# Altium schematic sheet arm_v3.SchDoc(arm_v3)
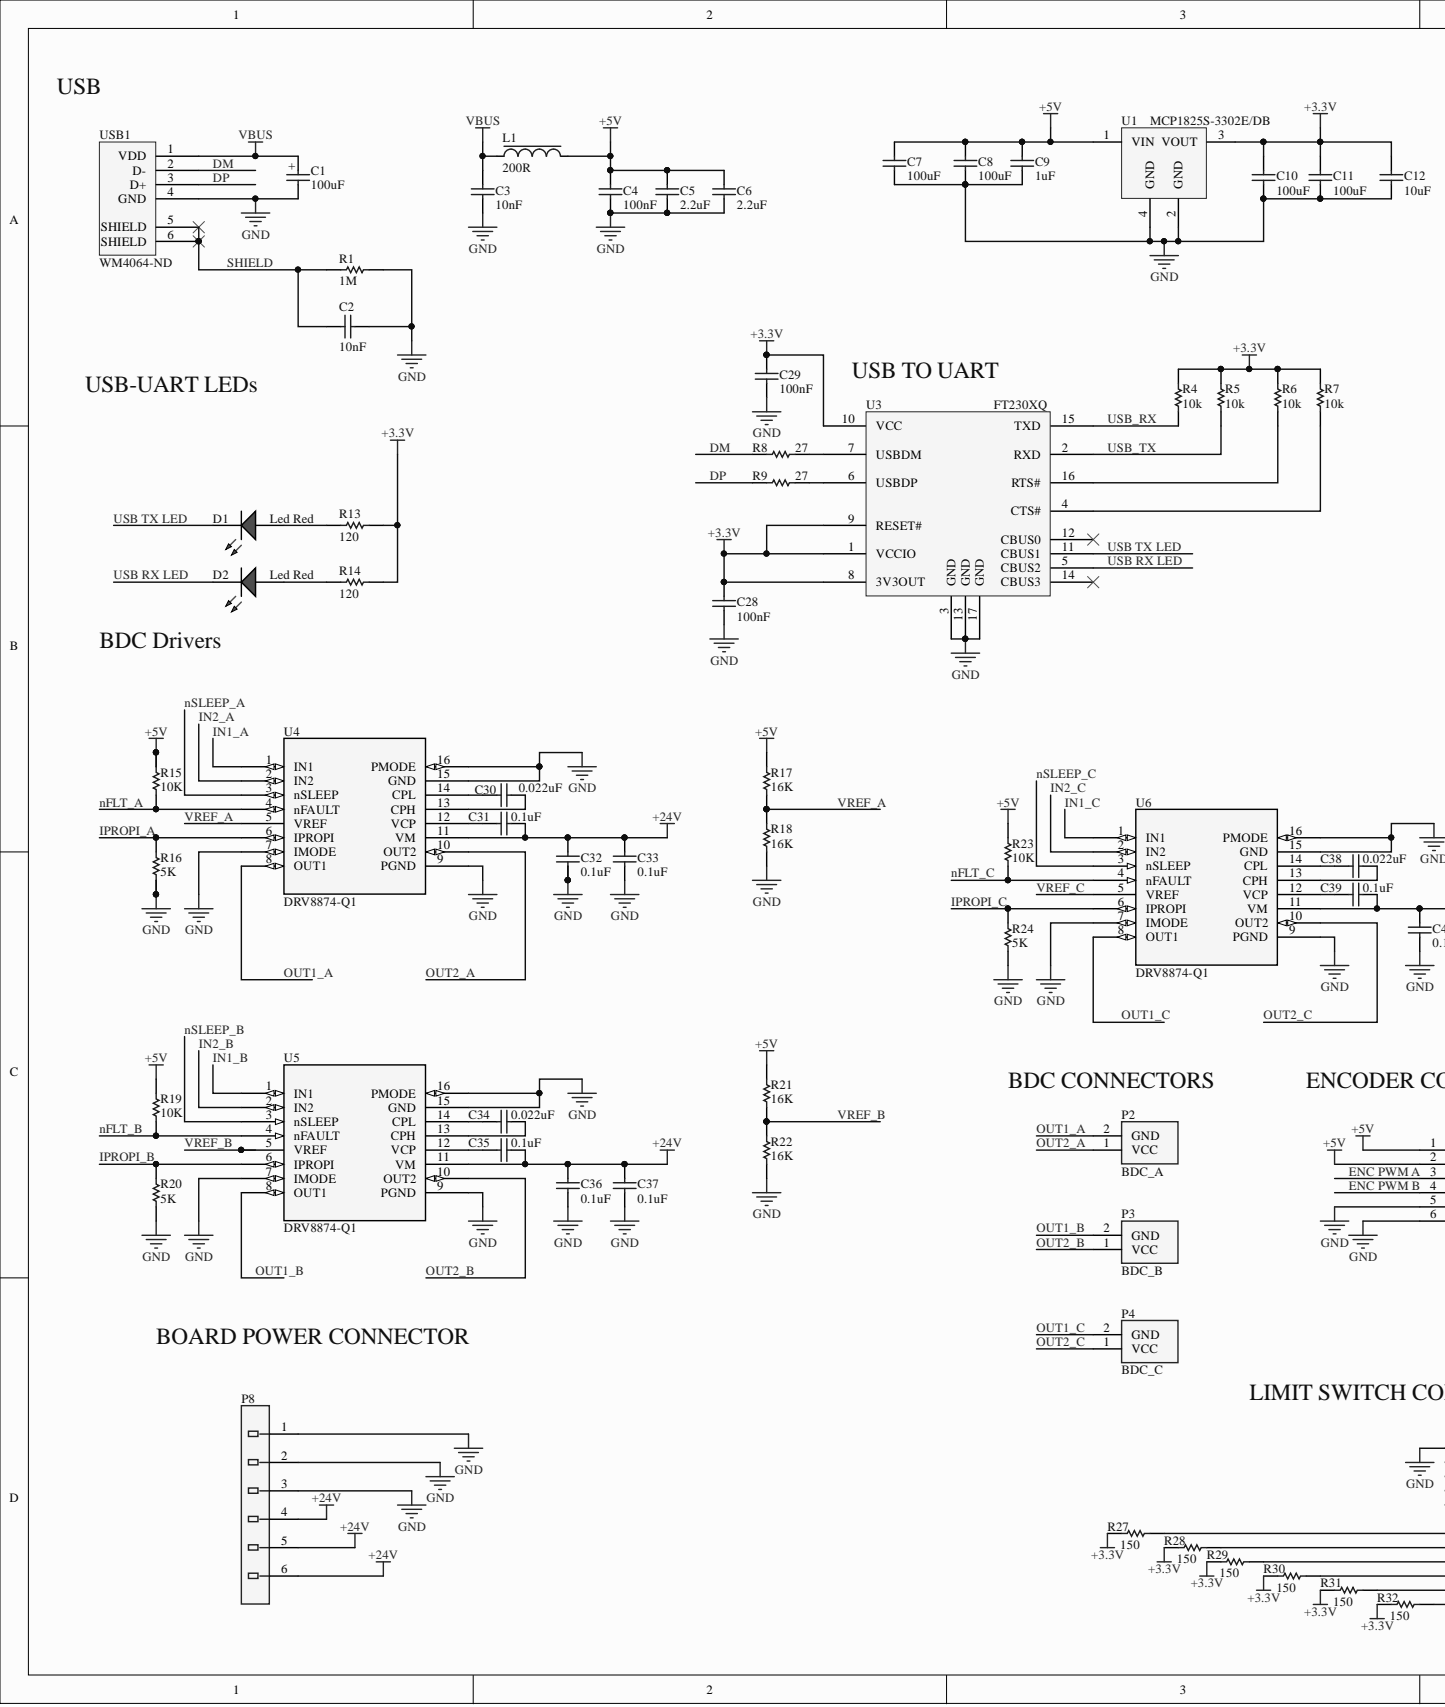
[diagram p0: arm_v3.SchDoc, left]
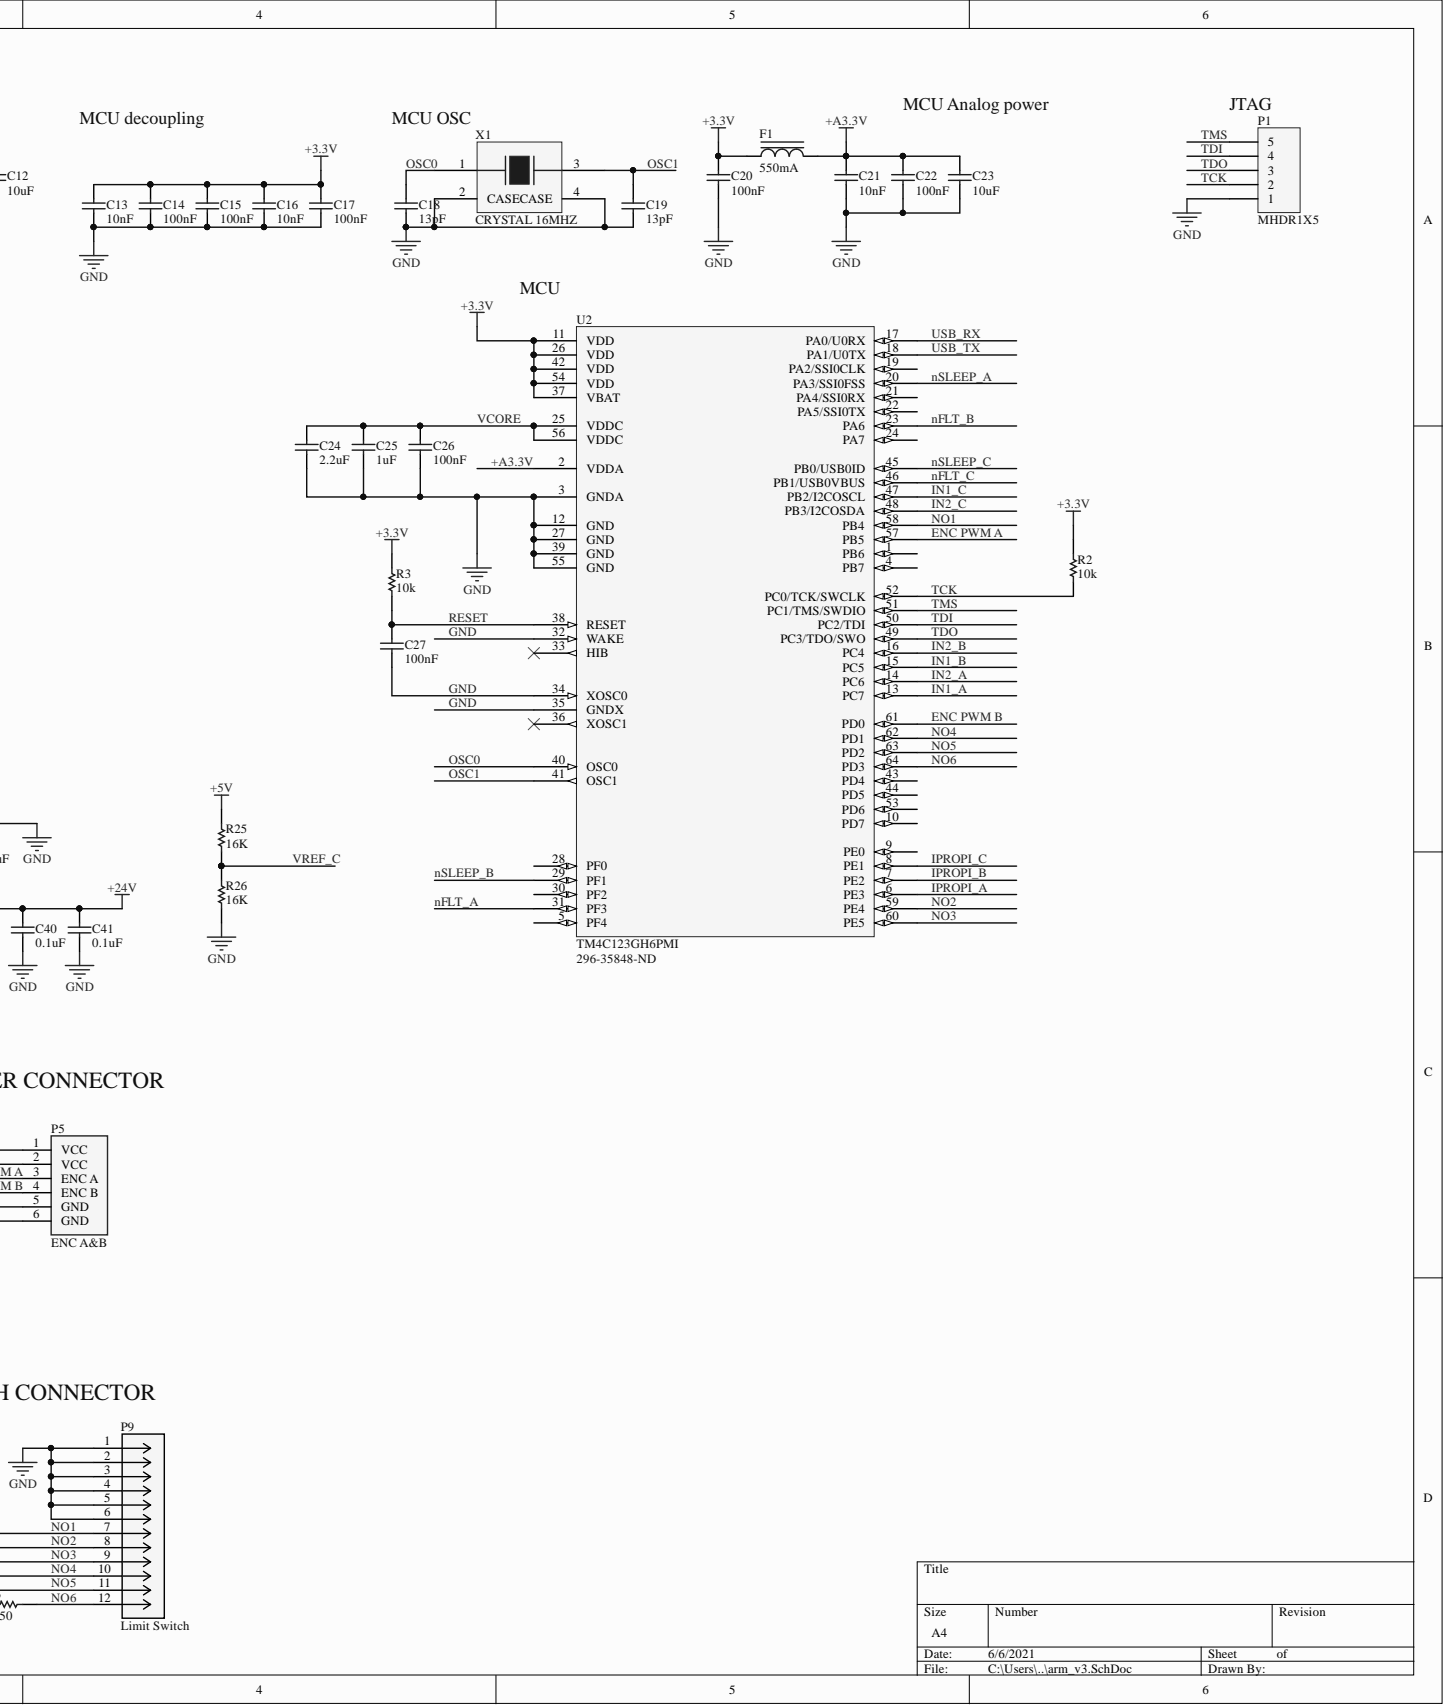
[diagram p1: arm_v3.SchDoc, right]

## Parts
C1  100uF  [CapAlu6.3mmx12.5mm]
C2  10nF  [CAP_1206]
C3  10nF  [CAP_0402_M]
C4  100nF  [CAP_0402_M]
C5  2.2uF  [CAP_0402_M]
C6  2.2uF  [CAP_0402_M]
C7  100uF  [CAP_1206]
C8  100uF  [CAP_1206]
C9  1uF  [CAP_0402_M]
C10  100uF  [CAP_1206]
C11  100uF  [CAP_1206]
C12  10uF  [CAP_0603]
C13  10nF  [CAP_0402_M]
C14  100nF  [CAP_0402_M]
C15  100nF  [CAP_0402_M]
C16  10nF  [CAP_0402_M]
C17  100nF  [CAP_0402_M]
C18  13pF  [CAP_0402_M]
C19  13pF  [CAP_0402_M]
C20  100nF  [CAP_0402_M]
C21  10nF  [CAP_0402_M]
C22  100nF  [CAP_0402_M]
C23  10uF  [CAP_0603]
C24  2.2uF  [CAP_0603]
C25  1uF  [CAP_0402_M]
C26  100nF  [CAP_0402_M]
C27  100nF  [CAP_0402_M]
C28  100nF  [CAP_0402_M]
C29  100nF  [CAP_0402_M]
C30  0.022uF  [CAP_0402_M]
C31  0.1uF  [CAP_0402_M]
C32  0.1uF  [CAP_0402_M]
C33  0.1uF  [CAP_0402_M]
C34  0.022uF  [CAP_0402_M]
C35  0.1uF  [CAP_0402_M]
C36  0.1uF  [CAP_0402_M]
C37  0.1uF  [CAP_0402_M]
C38  0.022uF  [CAP_0402_M]
C39  0.1uF  [CAP_0402_M]
C40  0.1uF  [CAP_0402_M]
C41  0.1uF  [CAP_0402_M]
D1  Led Red  Color: Red  [TOPLED 0805]
D2  Led Red  Color: Red  [TOPLED 0805]
F1  550mA  [RES_0402_M]
L1  200R  [IND_0603]
P1  MHDR1X5  [MHDR1X5]
P2  BDC_A  [436500227]
P3  BDC_B  [436500227]
P4  BDC_C  [436500227]
P5  ENC A&B  [1053091106]
P8  76825-0006  (value not drawn)
P9  Limit Switch  [CON_1053141112]
R1  1M  [RES_1206]
R2  10k  [RES_0402_M]
R3  10k  [RES_0402_M]
R4  10k  [RES_0402_M]
R5  10k  [RES_0402_M]
R6  10k  [RES_0402_M]
R7  10k  [RES_0402_M]
R8  27  [RES_0402_M]
R9  27  [RES_0402_M]
R13  120  [RES_0402_M]
R14  120  [RES_0402_M]
R15  10K  [RES_0402_M]
R16  5K  [RES_0402_M]
R17  16K  [RES_0402_M]
R18  16K  [RES_0402_M]
R19  10K  [RES_0402_M]
R20  5K  [RES_0402_M]
R21  16K  [RES_0402_M]
R22  16K  [RES_0402_M]
R23  10K  [RES_0402_M]
R24  5K  [RES_0402_M]
R25  16K  [RES_0402_M]
R26  16K  [RES_0402_M]
R27  150  [RES_0402_M]
R28  150  [RES_0402_M]
R29  150  [RES_0402_M]
R30  150  [RES_0402_M]
R31  150  [RES_0402_M]
R32  150  [RES_0402_M]
U1  MCP1825S-3302E/DB  [SOT230P700X180-4N]
U2  TM4C123GH6PMI  Supplier Part Number 1: 296-35848-ND  [TSQFP50P1200X1200X120-64N]
U3  FT230XQ  Protocol: USB  Interface: UART  [QFN65P400X400X80_HS-17N]
U4  DRV8874-Q1  [SOIC_PWPRQ1_TEX]
U5  DRV8874-Q1  [SOIC_PWPRQ1_TEX]
U6  DRV8874-Q1  [SOIC_PWPRQ1_TEX]
USB1  Supplier Part Number 1: WM4064-ND  [USB2.5-2H4E]  (hidden comment: USB type-B jack)
X1  CRYSTAL 16MHZ  [CRYSTAL_3.2x2.5]

## Nets
+3.3V: C10.1 C11.1 C12.1 C13.2 C14.2 C15.2 C16.2 C17.2 C20.2 C28.2 C29.2 F1.1 R2.1 R3.1 R4.2 R5.2 R6.2 R7.2 R13.2 R14.2 R27.2 R28.2 R29.2 R30.2 R31.2 R32.2 U1.3(VOUT) U2.11(VDD) U2.26(VDD) U2.37(VBAT) U2.42(VDD) U2.54(VDD) U3.1(VCCIO) U3.8(3V3OUT) U3.9(RESET#) U3.10(VCC)
+5V: C4.2 C5.2 C6.2 C7.1 C8.1 C9.2 L1.2 P5.1(VCC) P5.2(VCC) R15.2 R17.1 R19.1 R21.1 R23.1 R25.1 U1.1(VIN)
+24V: C31.2 C32.2 C33.2 C35.2 C36.2 C37.2 C39.2 C40.2 C41.2 P8.4 P8.5 P8.6 U4.11(VM) U5.11(VM) U6.11(VM)
VBUS: C1.1 C3.2 L1.1 USB1.1(VDD)
+A3.3V: C21.2 C22.2 C23.2 F1.2 U2.2(VDDA)
DM: R8.1 USB1.2(D-)
DP: R9.1 USB1.3(D+)
ENC PWM A: P5.3 U2.57(PB5)
ENC PWM B: P5.4 U2.61(PD0)
GND: C1.2 C2.1 C3.1 C4.1 C5.1 C6.1 C7.2 C8.2 C9.1 C10.2 C11.2 C12.2 C13.1 C14.1 C15.1 C16.1 C17.1 C18.1 C19.1 C20.1 C21.1 C22.1 C23.1 C24.1 C25.1 C26.1 C27.1 C28.1 C29.1 C32.1 C33.1 C36.1 C37.1 C40.1 C41.1 P1.1 P5.5(GND) P5.6(GND) P8.1 P8.2 P8.3 P9.1 P9.2 P9.3 P9.4 P9.5 P9.6 R1.1 R16.2 R18.2 R20.2 R22.2 R24.2 R26.2 U1.2(GND) U1.4(GND) U2.3(GNDA) U2.12(GND) U2.27(GND) U2.32(WAKE) U2.34(XOSC0) U2.35(GNDX) U2.39(GND) U2.55(GND) U3.3(GND) U3.13(GND) U3.17(GND) U4.7(IMODE) U4.9(PGND) U4.15(GND) U4.16(PMODE) U5.7(IMODE) U5.9(PGND) U5.15(GND) U5.16(PMODE) U6.7(IMODE) U6.9(PGND) U6.15(GND) U6.16(PMODE) USB1.4(GND) X1.2(CASE) X1.4(CASE)
IN1_A: U2.13(PC7) U4.1(IN1)
IN1_B: U2.15(PC5) U5.1(IN1)
IN1_C: U2.47(PB2/I2COSCL) U6.1(IN1)
IN2_A: U2.14(PC6) U4.2(IN2)
IN2_B: U2.16(PC4) U5.2(IN2)
IN2_C: U2.48(PB3/I2COSDA) U6.2(IN2)
IPROPI_A: R16.1 U2.6(PE3) U4.6(IPROPI)
IPROPI_B: R20.1 U2.7(PE2) U5.6(IPROPI)
IPROPI_C: R24.1 U2.8(PE1) U6.6(IPROPI)
nFLT_A: R15.1 U2.31(PF3) U4.4(nFAULT)
nFLT_B: R19.2 U2.23(PA6) U5.4(nFAULT)
nFLT_C: R23.2 U2.46(PB1/USB0VBUS) U6.4(nFAULT)
NO1: P9.7 R27.1 U2.58(PB4)
NO2: P9.8 R28.1 U2.59(PE4)
NO3: P9.9 R29.1 U2.60(PE5)
NO4: P9.10 R30.1 U2.62(PD1)
NO5: P9.11 R31.1 U2.63(PD2)
NO6: P9.12 R32.1 U2.64(PD3)
nSLEEP_A: U2.20(PA3/SSI0FSS) U4.3(nSLEEP)
nSLEEP_B: U2.29(PF1) U5.3(nSLEEP)
nSLEEP_C: U2.45(PB0/USB0ID) U6.3(nSLEEP)
OSC0: C18.2 U2.40(OSC0) X1.1
OSC1: C19.2 U2.41(OSC1) X1.3
OUT1_A: P2.2 U4.8(OUT1)
OUT1_B: P3.2 U5.8(OUT1)
OUT1_C: P4.2 U6.8(OUT1)
OUT2_A: P2.1 U4.10(OUT2)
OUT2_B: P3.1 U5.10(OUT2)
OUT2_C: P4.1 U6.10(OUT2)
RESET: C27.2 R3.2 U2.38(RESET)
SHIELD: C2.2 R1.2 USB1.5(SHIELD) USB1.6(SHIELD)
TCK: P1.2 R2.2 U2.52(PC0/TCK/SWCLK)
TDI: P1.4 U2.50(PC2/TDI)
TDO: P1.3 U2.49(PC3/TDO/SWO)
TMS: P1.5 U2.51(PC1/TMS/SWDIO)
USB RX LED: D2.2 U3.5(CBUS2)
USB TX LED: D1.2 U3.11(CBUS1)
USB_RX: R4.1 U2.17(PA0/U0RX) U3.15(TXD)
USB_TX: R5.1 U2.18(PA1/U0TX) U3.2(RXD)
VCORE: C24.2 C25.2 C26.2 U2.25(VDDC) U2.56(VDDC)
VREF_A: R17.2 R18.1 U4.5(VREF)
VREF_B: R21.2 R22.1 U5.5(VREF)
VREF_C: R25.2 R26.1 U6.5(VREF)
NetC30_1: C30.1 U4.14(CPL)
NetC30_2: C30.2 U4.13(CPH)
NetC31_1: C31.1 U4.12(VCP)
NetC34_1: C34.1 U5.14(CPL)
NetC34_2: C34.2 U5.13(CPH)
NetC35_1: C35.1 U5.12(VCP)
NetC38_1: C38.1 U6.14(CPL)
NetC38_2: C38.2 U6.13(CPH)
NetC39_1: C39.1 U6.12(VCP)
NetD1_1: D1.1 R13.1
NetD2_1: D2.1 R14.1
NetR6_1: R6.1 U3.16(RTS#)
NetR7_1: R7.1 U3.4(CTS#)
NetR8_2: R8.2 U3.7(USBDM)
NetR9_2: R9.2 U3.6(USBDP)

Not connected: U2 1(PB6) 4(PB7) 5(PF4) 9(PE0) 10(PD7) 19(PA2/SSI0CLK) 21(PA4/SSI0RX) 22(PA5/SSI0TX) 24(PA7) 28(PF0) 30(PF2) 33(HIB) 36(XOSC1) 43(PD4) 44(PD5) 53(PD6); U3 12(CBUS0) 14(CBUS3)

## Library data of the parts
C1 = 100uF_35v_6.3x12.5: Capacitor 35v 100uF 6.3mm; Manufacturer Part Number: UHV1V101MED; Manufacturer: Nichicon; Tolerance: ±20%; Ripple Current: 220mA @ 120Hz; Polarization: Polar; Lifetime @ Temp.: 5000 Hrs @ 105°C; Impedance: 100 mOhm; Capacitance: 100µF; Voltage Rating: 35V; Surface Mount Land Size: -; Supplier Part Number 1: 493-13394-ND; Supplier 1: Digi-Key; Stock 1: 5561; Size / Dimension: 0.248" Dia (6.30mm); RoHS: RoHS Compliant; Pricing 1: 1=0.46, 10=0.32, 25=0.262, 50=0.224, 100=0.1919, 250=0.15992, 500=0.14394, 1000=0.12155, 2500=0.11515 (CAD); Packaging: Bulk; Package / Case: Radial, Can; Operating Temperature: -40°C ~ 105°C; Mounting Type: Through Hole; Library Name: Capacitors.SchLib; Lead Spacing: 0.098" (2.50mm); Height - Seated (Max): 0.492" (12.50mm); ESR (Equivalent Series Resistance): -; ComponentLink1URL: http://nichicon-us.com/english/products/pdfs/e-uhv.pdf; ComponentLink1Description: http://nichicon-us.com/english/products/pdfs/e-uhv.pdf; Component Kind: Standard; Category: Capacitors; Applications: General Purpose
C2 = 10nF_500V_1206: CAP CER 10000PF 500V X7R 1206; Manufacturer Part Number: CL31B103KGFNNNE; Manufacturer: Samsung Electro-Mechanics America, Inc; Tolerance: ±10%; Voltage - Rated: 500V; Thickness (Max): 0.055" (1.40mm); Temperature Coefficient: X7R; Supplier Part Number 1: 1276-3076-1-ND; Supplier 1: Digi-Key; Stock 1: 9075; Size / Dimension: 0.126" L x 0.063" W (3.20mm x 1.60mm); RoHS: RoHS Compliant; Ratings: -; Pricing 1: 1=0.34, 10=0.28, 25=0.192, 100=0.1398, 500=0.09266, 1000=0.08216 (CAD); Packaging: Cut Tape (CT); Package / Case: 1206 (3216 Metric); Operating Temperature: -55°C ~ 125°C; Mounting Type: Surface Mount, MLCC; Library Name: Capacitors.SchLib; Lead Style: -; Lead Spacing: -; Height - Seated (Max): -; Features: -; ComponentLink1URL: http://www.samsungsem.com/kr/front/downloadcms.do?path=/kr/support/product-search/mlcc/__icsFiles/afieldfile/2014/11/05&fileName=S_CL31B103KGFNNNE.pdf; ComponentLink1Description: http://www.samsungsem.com/kr/front/downloadcms.do?path=/kr/support/product-search/mlcc/__icsFiles/afieldfile/2014/11/05&fileName=S_CL31B103KGFNNNE.pdf; Component Kind: Standard; Category: Capacitors; Capacitance: 10000pF; Applications: General Purpose
C3 C13 C16 C21 = 10nF_50V_0402: CAP CER 10000PF 50V 10% X7R 0402; Manufacturer Part Number: C0402C103K5RACTU; Manufacturer: Kemet; Voltage - Rated: 50V; Tolerance: ±10%; Thickness (Max): 0.022" (0.55mm); Temperature Coefficient: X7R; Supplier Part Number 1: 399-3066-1-ND; Supplier 1: Digi-Key; Stock 1: 3556921; Size / Dimension: 0.039" L x 0.020" W (1.00mm x 0.50mm); RoHS: RoHS Compliant; Ratings: -; Pricing 1: 1=0.14, 10=0.037, 25=0.026, 50=0.0198, 100=0.0166, 250=0.01364, 1000=0.00893, 2500=0.00804, 5000=0.00744 (CAD); Packaging: Cut Tape (CT); Package / Case: 0402 (1005 Metric); Operating Temperature: -55°C ~ 125°C; Mounting Type: Surface Mount, MLCC; Library Name: Capacitors.SchLib; Lead Style: -; Lead Spacing: -; Height - Seated (Max): -; Features: -; ComponentLink1URL: http://www.kemet.com/docfinder?Partnumber=C0402C103K5RACTU; ComponentLink1Description: http://www.kemet.com/docfinder?Partnumber=C0402C103K5RACTU; Component Kind: Standard; Category: Capacitors; Capacitance: 10000pF; Applications: General Purpose
C4 C14 C15 C17 C20 C22 C26-C41 = 100nF_50V_0402: CAP CER 100NF 50V 20% X5R 0402; Manufacturer Part Number: C1005X5R1H104M050BB; Manufacturer: TDK Corporation; Capacitance: 0.1µF; Voltage - Rated: 50V; Tolerance: ±20%; Thickness (Max): 0.022" (0.55mm); Temperature Coefficient: X5R; Supplier Part Number 1: 445-5943-1-ND; Supplier 1: Digi-Key; Stock 1: 218263; Size / Dimension: 0.039" L x 0.020" W (1.00mm x 0.50mm); RoHS: RoHS Compliant; Ratings: -; Pricing 1: 1=0.18, 10=0.122, 100=0.0579, 250=0.04872, 500=0.0408, 1000=0.03348, 5000=0.02831 (CAD); Packaging: Cut Tape (CT); Package / Case: 0402 (1005 Metric); Operating Temperature: -55°C ~ 85°C; Mounting Type: Surface Mount, MLCC; Library Name: Capacitors.SchLib; Lead Style: -; Lead Spacing: -; Height - Seated (Max): -; Features: -; ComponentLink1URL: http://product.tdk.com/en/catalog/datasheets/mlcc_commercial_general_en.pdf; ComponentLink1Description: http://product.tdk.com/en/catalog/datasheets/mlcc_commercial_general_en.pdf; Component Kind: Standard; Category: Capacitors; Applications: General Purpose
C5 C6 = 2.2uF_10V_0402: CAP CER 2.2UF 10V 20% X5R 0402; Manufacturer Part Number: LMK105BJ225MV-F; Manufacturer: Taiyo Yuden; Capacitance: 2.2µF; Voltage - Rated: 10V; Tolerance: ±20%; Thickness (Max): 0.022" (0.55mm); Temperature Coefficient: X5R; Supplier Part Number 1: 587-3153-1-ND; Supplier 1: Digi-Key; Stock 1: 258065; Size / Dimension: 0.039" L x 0.020" W (1.00mm x 0.50mm); RoHS: RoHS Compliant; Ratings: -; Pricing 1: 1=0.26, 10=0.173, 50=0.105, 100=0.0881, 250=0.07132, 500=0.0629, 1000=0.05348, 2500=0.04928, 5000=0.04509 (CAD); Packaging: Cut Tape (CT); Package / Case: 0402 (1005 Metric); Operating Temperature: -55°C ~ 85°C; Mounting Type: Surface Mount, MLCC; Library Name: Capacitors.SchLib; Lead Style: -; Lead Spacing: -; Height - Seated (Max): -; Features: -; ComponentLink1URL: http://www.yuden.co.jp/ut/product/category/capacitor/LMK105BJ225MV-F.pdf; ComponentLink1Description: http://www.yuden.co.jp/ut/product/category/capacitor/LMK105BJ225MV-F.pdf; Component Kind: Standard; Category: Capacitors; Applications: General Purpose
C7 C8 C10 C11 = 100uF_6.3v_1206_X5R: CAP CER 100UF 6.3V X5R 1206; Manufacturer Part Number: GRM31CR60J107ME39L; Manufacturer: Murata Electronics North America; Tolerance: ±20%; Capacitance: 100µF; Voltage - Rated: 6.3V; Thickness (Max): 0.071" (1.80mm); Temperature Coefficient: X5R; Supplier Part Number 1: 490-4539-1-ND; Supplier 1: Digi-Key; Stock 1: 450179; Size / Dimension: 0.126" L x 0.063" W (3.20mm x 1.60mm); RoHS: RoHS Compliant; Ratings: -; Pricing 1: 1=1.23, 10=1.048, 50=0.771, 100=0.6128, 250=0.51396, 500=0.46456, 1000=0.42502 (CAD); Packaging: Cut Tape (CT); Package / Case: 1206 (3216 Metric); Operating Temperature: -55°C ~ 85°C; Mounting Type: Surface Mount, MLCC; Library Name: Capacitors.SchLib; Lead Style: -; Lead Spacing: -; Height - Seated (Max): -; Features: -; ComponentLink1URL: http://www.murata.com/~/media/webrenewal/support/library/catalog/products/capacitor/mlcc/c02e.pdf; ComponentLink1Description: http://www.murata.com/~/media/webrenewal/support/library/catalog/products/capacitor/mlcc/c02e.pdf; Component Kind: Standard; Category: Capacitors; Applications: General Purpose
C9 C25 = 1uF_25V_0402: CAP CER 1UF 25V 10% X5R 0402; Manufacturer Part Number: C1005X5R1E105K050BC; Manufacturer: TDK Corporation; Capacitance: 1µF; Voltage - Rated: 25V; Tolerance: ±10%; Thickness (Max): 0.022" (0.55mm); Temperature Coefficient: X5R; Supplier Part Number 1: 445-9066-1-ND; Supplier 1: Digi-Key; Stock 1: 310467; Size / Dimension: 0.039" L x 0.020" W (1.00mm x 0.50mm); RoHS: RoHS Compliant; Ratings: -; Pricing 1: 1=0.27, 10=0.185, 100=0.0878, 250=0.074, 500=0.06196, 1000=0.05087, 5000=0.04301 (CAD); Packaging: Cut Tape (CT); Package / Case: 0402 (1005 Metric); Operating Temperature: -55°C ~ 85°C; Mounting Type: Surface Mount, MLCC; Library Name: Capacitors.SchLib; Lead Style: -; Lead Spacing: -; Height - Seated (Max): -; Features: -; ComponentLink1URL: http://product.tdk.com/en/catalog/datasheets/mlcc_commercial_general_en.pdf; ComponentLink1Description: http://product.tdk.com/en/catalog/datasheets/mlcc_commercial_general_en.pdf; Component Kind: Standard; Category: Capacitors; Applications: General Purpose
C12 C23 = 10uF_10V_0603: CAP CER 10UF 10V 20% X5R 0603; Manufacturer Part Number: LMK107BBJ106MAHT; Manufacturer: Taiyo Yuden; Capacitance: 10µF; Voltage - Rated: 10V; Tolerance: ±20%; Thickness (Max): 0.035" (0.90mm); Temperature Coefficient: X5R; Supplier Part Number 1: 587-3412-1-ND; Supplier 1: Digi-Key; Stock 1: 90195; Size / Dimension: 0.063" L x 0.031" W (1.60mm x 0.80mm); RoHS: RoHS Compliant; Ratings: AEC-Q200; Pricing 1: 1=0.5, 10=0.437, 50=0.266, 100=0.2189, 250=0.17516, 500=0.15952, 1000=0.14107 (CAD); Packaging: Cut Tape (CT); Package / Case: 0603 (1608 Metric); Operating Temperature: -55°C ~ 85°C; Mounting Type: Surface Mount, MLCC; Library Name: Capacitors.SchLib; Lead Style: -; Lead Spacing: -; Height - Seated (Max): -; Features: -; ComponentLink1URL: http://www.yuden.co.jp/productdata/catalog/en/mlcc01_hq_e.pdf; ComponentLink1Description: http://www.yuden.co.jp/productdata/catalog/en/mlcc01_hq_e.pdf; Component Kind: Standard; Category: Capacitors; Applications: Automotive
C18 C19 = 13pF_50V_0402: CAP CER 13PF 50V 5% NP0 0402; Manufacturer Part Number: CL05C130JB5NNNC; Manufacturer: Samsung Electro-Mechanics America, Inc; Temperature Coefficient: C0G, NP0; Voltage - Rated: 50V; Tolerance: ±5%; Thickness (Max): 0.022" (0.55mm); Supplier Part Number 1: 1276-1642-1-ND; Supplier 1: Digi-Key; Stock 1: 16490; Size / Dimension: 0.039" L x 0.020" W (1.00mm x 0.50mm); RoHS: RoHS Compliant; Ratings: -; Pricing 1: 1=0.14, 10=0.064, 25=0.0448, 100=0.0291, 500=0.02032, 1000=0.01583, 2500=0.01425, 5000=0.01319 (CAD); Packaging: Cut Tape (CT); Package / Case: 0402 (1005 Metric); Operating Temperature: -55°C ~ 125°C; Mounting Type: Surface Mount, MLCC; Library Name: Capacitors.SchLib; Lead Style: -; Lead Spacing: -; Height - Seated (Max): -; Features: -; ComponentLink1URL: http://www.samsungsem.com/kr/front/downloadcms.do?path=/kr/support/product-search/mlcc/__icsFiles/afieldfile/2014/11/05&fileName=S_CL05C130JB5NNNC.pdf; ComponentLink1Description: http://www.samsungsem.com/kr/front/downloadcms.do?path=/kr/support/product-search/mlcc/__icsFiles/afieldfile/2014/11/05&fileName=S_CL05C130JB5NNNC.pdf; Component Kind: Standard; Category: Capacitors; Capacitance: 13pF; Applications: General Purpose
C24 = 2.2uF_35V_0603: CAP CER 2.2UF 35V 10% X5R 0603; Manufacturer Part Number: GRM188R6YA225KA12D; Manufacturer: Murata Electronics North America; Capacitance: 2.2µF; Voltage - Rated: 35V; Tolerance: ±10%; Thickness (Max): 0.031" (0.80mm); Temperature Coefficient: X5R; Supplier Part Number 1: 490-7204-1-ND; Supplier 1: Digi-Key; Stock 1: 55248; Size / Dimension: 0.063" L x 0.031" W (1.60mm x 0.80mm); RoHS: RoHS Compliant; Ratings: -; Pricing 1: 1=0.31, 10=0.214, 50=0.1298, 100=0.109, 250=0.08832, 500=0.07792, 1000=0.06624 (CAD); Packaging: Cut Tape (CT); Package / Case: 0603 (1608 Metric); Operating Temperature: -55°C ~ 85°C; Mounting Type: Surface Mount, MLCC; Library Name: Capacitors.SchLib; Lead Style: -; Lead Spacing: -; Height - Seated (Max): -; Features: -; ComponentLink1URL: http://www.murata.com/~/media/webrenewal/support/library/catalog/products/capacitor/mlcc/c02e.ashx; ComponentLink1Description: http://www.murata.com/~/media/webrenewal/support/library/catalog/products/capacitor/mlcc/c02e.ashx; Component Kind: Standard; Category: Capacitors; Applications: General Purpose
D1 D2 = TOPLED 0803: LED TOPLED 630NM SUP RED CLR SMD; Manufacturer Part Number: LS M67K-H2L1-1-Z; Manufacturer: OSRAM Opto Semiconductors Inc; Wavelength - Peak: 643nm; Voltage - Forward (Vf) (Typ): 1.8V; Viewing Angle: 120°; Millicandela Rating: 8.78mcd; Luminous Flux @ Current - Test: 25 mlm; Lens Type: Clear; Lens Style/Size: Rectangle with Flat Top, 1.5mm x 1mm; Current - Test: 2mA; Wavelength - Dominant: 630nm; Supplier Part Number 1: 475-2510-1-ND; Supplier 1: Digi-Key; Stock 1: 23262; Size / Dimension: 2.20mm L x 1.40mm W; RoHS: RoHS Compliant; Pricing 1: 1=0.19, 10=0.162, 100=0.1295, 250=0.11328, 500=0.10354 (CAD); Packaging: Cut Tape (CT); Package / Case: 2-SMD, J-Lead; Mounting Type: Surface Mount; Library Name: Diodes.SchLib; Height: 1.30mm; ComponentLink1URL: http://media.digikey.com/pdf/Data%20Sheets/Osram%20PDFs/LS,LO,LY_M67K.pdf; ComponentLink1Description: http://media.digikey.com/pdf/Data%20Sheets/Osram%20PDFs/LS,LO,LY_M67K.pdf; Component Kind: Standard; Category: Optoelectronics
F1 = 40O_550mA_0402: FERRITE CHIP 40 OHM 550MA 0402; Manufacturer Part Number: MMZ1005Y400CT000; Manufacturer: TDK Corporation; Impedance @ Frequency: 40 Ohm @ 100MHz; Filter Type: Differential Mode - Single; DC Resistance (DCR): 120 mOhm Max; Supplier Part Number 1: 445-2150-1-ND; Supplier 1: Digi-Key; Stock 1: 1706; Size / Dimension: 0.039" L x 0.020" W (1.00mm x 0.50mm); RoHS: RoHS Compliant; Pricing 1: 1=0.14, 10=0.099, 100=0.059, 250=0.04284, 500=0.03928, 1000=0.03393, 5000=0.02679 (CAD); Packaging: Cut Tape (CT); Package / Case: 0402 (1005 Metric); Mounting Type: Surface Mount; Library Name: Resistors.SchLib; Height (Max): 0.022" (0.55mm); Current Rating: 550mA; ComponentLink1URL: http://product.tdk.com/emc/beads/en/documents/beads_commercial_signal_mmz_en.pdf; ComponentLink1Description: http://product.tdk.com/emc/beads/en/documents/beads_commercial_signal_mmz_en.pdf; Component Kind: Standard; Category: Filters
L1 = Ferrite_200 ohms_2A: FILTER CHIP 220 OHM 2A 0603; Manufacturer Part Number: BLM18EG221SN1D; Manufacturer: Murata Electronics North America; Impedance @ Frequency: 220 Ohm @ 100MHz; Filter Type: Differential Mode - Single; DC Resistance (DCR): 50 mOhm Max; Supplier Part Number 1: 490-3992-1-ND; Supplier 1: Digi-Key; Stock 1: 382631; Size / Dimension: 0.063" L x 0.031" W (1.60mm x 0.80mm); RoHS: RoHS Compliant; Pricing 1: 1=0.33, 10=0.254, 50=0.1814, 100=0.1632, 250=0.13784, 500=0.12332, 1000=0.10519 (CAD); Packaging: Cut Tape (CT); Package / Case: 0603 (1608 Metric); Mounting Type: Surface Mount; Library Name: Inductors.SchLib; Height (Max): 0.037" (0.95mm); Current Rating: 2A; ComponentLink1URL: http://search.murata.co.jp/Ceramy/image/img/PDF/ENG/L0110S0101BLM18E.pdf; ComponentLink1Description: http://search.murata.co.jp/Ceramy/image/img/PDF/ENG/L0110S0101BLM18E.pdf; Component Kind: Standard; Category: Filters
P1 = MHDR1X5: Header, 5-Pin; Signal Integrity: Connector; Library Name: Miscellaneous Connectors.IntLib; LatestRevisionNote: Re-released for DXP Platform.; LatestRevisionDate: 17-Jul-2002; Component Kind: Standard; Publisher: Altium Limited
P2-P4 = 43650-0227: Connector; Manufacturer_Name: Molex; Manufacturer_Part_Number: 43650-0227; Height: 10.15mm; Datasheet Link: https://www.molex.com/pdm_docs/sd/436500227_sd.pdf; Component Kind: Standard
P5 = 105309-1106: Connector; Manufacturer_Name: Molex; Manufacturer_Part_Number: 105309-1106; Height: 10.71mm; Datasheet Link: https://rs.componentsearchengine.com/Datasheets/2/105309-1106.pdf; Component Kind: Standard
P9 = 1053141112: No Description Available; Vendor: Molex Connector Corporation; SourceLibrary: Add_to_database_2021-01-18; Type: DEV; RefDes: RefDes; Manufacturer_Part_Number: 1053141112; Library Name: Molex 1053141112.SchLib; Component Kind: Standard; Copyright: Copyright (C) 2018 Accelerated Designs. All rights reserved
R1 = 1M_5%_1206: RES SMD 1M OHM 5% 1/4W 1206; Manufacturer Part Number: ERJ-8GEYJ105V; Manufacturer: Panasonic Electronic Components; Temperature Coefficient: ±200ppm/°C; Power (Watts): 0.25W, 1/4W; Tolerance: ±5%; Supplier Part Number 1: P1.0MECT-ND; Supplier Device Package: 1206; Supplier 1: Digi-Key; Stock 1: 165352; Size / Dimension: 0.126" L x 0.063" W (3.20mm x 1.60mm); RoHS: RoHS Compliant; Resistance (Ohms): 1M; Pricing 1: 1=0.14, 50=0.038, 100=0.029, 250=0.02152, 500=0.01588, 1000=0.01059, 2500=0.00894 (CAD); Packaging: Cut Tape (CT); Package / Case: 1206 (3216 Metric); Operating Temperature: -55°C ~ 155°C; Number of Terminations: 2; Library Name: Resistors.SchLib; Height: 0.028" (0.70mm); Features: Automotive AEC-Q200; Composition: Thick Film; ComponentLink1URL: http://industrial.panasonic.com/www-cgi/jvcr13pz.cgi?E+PZ+3+AOA0001+ERJ8GEYJ105V+7+WW; ComponentLink1Description: http://industrial.panasonic.com/www-cgi/jvcr13pz.cgi?E+PZ+3+AOA0001+ERJ8GEYJ105V+7+WW; Component Kind: Standard; Category: Resistors
R2-R7 R27-R32 = 10k_1%_0402: RES SMD 10K OHM 1% 1/10W 0402; Manufacturer Part Number: ERJ-2RKF1002X; Manufacturer: Panasonic Electronic Components; Temperature Coefficient: ±100ppm/°C; Power (Watts): 0.1W, 1/10W; Tolerance: ±1%; Supplier Part Number 1: P10.0KLCT-ND; Supplier Device Package: 0402; Supplier 1: Digi-Key; Stock 1: 5818216; Size / Dimension: 0.039" L x 0.020" W (1.00mm x 0.50mm); RoHS: RoHS Compliant; Resistance (Ohms): 10k; Pricing 1: 1=0.14, 50=0.0282, 100=0.0215, 250=0.01592, 500=0.01176, 1000=0.00784, 5000=0.00551 (CAD); Packaging: Cut Tape (CT); Package / Case: 0402 (1005 Metric); Operating Temperature: -55°C ~ 155°C; Number of Terminations: 2; Library Name: Resistors.SchLib; Height: 0.016" (0.40mm); Features: Automotive AEC-Q200; Composition: Thick Film; ComponentLink1URL: http://industrial.panasonic.com/www-cgi/jvcr13pz.cgi?E+PZ+3+AOA0002+ERJ2RKF1002X+7+WW; ComponentLink1Description: http://industrial.panasonic.com/www-cgi/jvcr13pz.cgi?E+PZ+3+AOA0002+ERJ2RKF1002X+7+WW; Component Kind: Standard; Category: Resistors
R8 R9 = 27_1%_0402: RES SMD 27 OHM 1% 1/10W 0402; Manufacturer Part Number: ERJ-2RKF27R0X; Manufacturer: Panasonic Electronic Components; Temperature Coefficient: ±100ppm/°C; Power (Watts): 0.1W, 1/10W; Tolerance: ±1%; Supplier Part Number 1: P27.0LCT-ND; Supplier Device Package: 0402; Supplier 1: Digi-Key; Stock 1: 490131; Size / Dimension: 0.039" L x 0.020" W (1.00mm x 0.50mm); RoHS: RoHS Compliant; Resistance (Ohms): 27; Pricing 1: 1=0.16, 50=0.0234, 100=0.0171, 250=0.01308, 500=0.01048, 1000=0.00771, 5000=0.00553 (CAD); Packaging: Cut Tape (CT); Package / Case: 0402 (1005 Metric); Operating Temperature: -55°C ~ 155°C; Number of Terminations: 2; Library Name: Resistors.SchLib; Height: 0.016" (0.40mm); Features: Automotive AEC-Q200; Composition: Thick Film; ComponentLink1URL: http://industrial.panasonic.com/www-cgi/jvcr13pz.cgi?E+PZ+3+AOA0002+ERJ2RKF27R0X+7+WW; ComponentLink1Description: http://industrial.panasonic.com/www-cgi/jvcr13pz.cgi?E+PZ+3+AOA0002+ERJ2RKF27R0X+7+WW; Component Kind: Standard; Category: Resistors
R13 R14 = 120_1%_0402: RES SMD 120 OHM 1% 1/10W 0402; Manufacturer Part Number: ERJ-2RKF1200X; Manufacturer: Panasonic Electronic Components; Temperature Coefficient: ±100ppm/°C; Power (Watts): 0.1W, 1/10W; Tolerance: ±1%; Supplier Part Number 1: P120LCT-ND; Supplier Device Package: 0402; Supplier 1: Digi-Key; Stock 1: 184236; Size / Dimension: 0.039" L x 0.020" W (1.00mm x 0.50mm); RoHS: RoHS Compliant; Resistance (Ohms): 120; Pricing 1: 1=0.14, 50=0.0282, 100=0.0215, 250=0.01592, 500=0.01176, 1000=0.00784, 5000=0.00551 (CAD); Packaging: Cut Tape (CT); Package / Case: 0402 (1005 Metric); Operating Temperature: -55°C ~ 155°C; Number of Terminations: 2; Library Name: Resistors.SchLib; Height: 0.016" (0.40mm); Features: Automotive AEC-Q200; Composition: Thick Film; ComponentLink1URL: http://industrial.panasonic.com/www-cgi/jvcr13pz.cgi?E+PZ+3+AOA0002+ERJ2RKF1200X+7+WW; ComponentLink1Description: http://industrial.panasonic.com/www-cgi/jvcr13pz.cgi?E+PZ+3+AOA0002+ERJ2RKF1200X+7+WW; Component Kind: Standard; Category: Resistors
R15-R26 = 0_0402: RES SMD 0 OHM JUMPER 1/16W 0402; Manufacturer Part Number: RC0402FR-070RL; Manufacturer: Yageo; Power (Watts): 0.063W, 1/16W; Tolerance: Jumper; Temperature Coefficient: -; Supplier Part Number 1: 311-0.0LRCT-ND; Supplier Device Package: 0402; Supplier 1: Digi-Key; Stock 1: 548262; Size / Dimension: 0.039" L x 0.020" W (1.00mm x 0.50mm); RoHS: RoHS Compliant; Pricing 1: 1=0.14, 10=0.017, 25=0.012, 50=0.009, 100=0.0068, 250=0.00516, 500=0.00414, 1000=0.00305, 5000=0.00219 (CAD); Part Status: Active; Packaging: Cut Tape (CT); Package / Case: 0402 (1005 Metric); Operating Temperature: -55°C ~ 155°C; Library Name: Resistors.SchLib; Height - Seated (Max): 0.016" (0.40mm); Features: Moisture Resistant; Failure Rate: -; Composition: Thick Film; ComponentLink1URL: http://www.yageo.com/documents/recent/PYu-RC_Group_51_RoHS_L_8.pdf; ComponentLink1Description: http://www.yageo.com/documents/recent/PYu-RC_Group_51_RoHS_L_8.pdf; Component Kind: Standard; Category: Resistors
U1 = MCP1825S-3302E/DB: 500 mA, Low Voltage, Low Quiescent Current LDO Regulator; Manufacturer: Microchip Technology; Voltage - Output: 3.3V; Voltage - Input: Up to 6V; Voltage - Dropout (Typical): 0.21V @ 500mA; Regulator Topology: Positive Fixed; Number of Regulators: 1; Current - Output: 500mA; Supplier Part Number 1: MCP1825S-3302E/DB-ND; Supplier Device Package: SOT-223-3; Supplier 1: Digi-Key; Stock 1: 5172; RoHS: RoHS Compliant; Pricing 1: 1=0.61, 10=0.51, 25=0.43, 100=0.39 (USD); Packaging: Tube; Package / Case: TO-261-4, TO-261AA; Operating Temperature: -40°C ~ 125°C; Mounting Type: Surface Mount; Manufacturer Part Number: MCP1825S-3302E/DB; Library Name: ICs.SchLib; Current - Limit (Min): -; ComponentLink1URL: http://www.microchip.com/mymicrochip/filehandler.aspx?ddocname=en531698; ComponentLink1Description: http://www.microchip.com/mymicrochip/filehandler.aspx?ddocname=en531698; Component Kind: Standard; Category: Integrated Circuits (ICs)
U2 = TM4C123GH6PMI: Tiva TM4C123GH6PM Microcontroller ARM Cortex 80 MHz FPU; Supplier 1: Digi-Key; Library Name: ICs.SchLib; Component Kind: Standard
U3 = FT230XQ-R: IC USB SERIAL BASIC UART 16QFN; Manufacturer: FTDI, Future Technology Devices International Ltd; Voltage - Supply: 3.3 V ~ 5 V; Standards: USB 2.0; Function: Bridge, USB to UART; Current - Supply: 8mA; Supplier Part Number 1: 768-1130-1-ND; Supplier Device Package: 16-QFN (4x4); Supplier 1: Digi-Key; Stock 1: 7567; RoHS: RoHS Compliant; Pricing 1: 1=2.64, 25=2.5508, 100=2.4601, 250=2.21412, 500=1.994, 1000=1.89042, 2500=1.86128 (CAD); Packaging: Cut Tape (CT); Package / Case: 16-WQFN Exposed Pad; Operating Temperature: -40°C ~ 85°C; Manufacturer Part Number: FT230XQ-R; Library Name: ICs.SchLib; ComponentLink1URL: http://www.ftdichip.com/Support/Documents/DataSheets/ICs/DS_FT230X.pdf; ComponentLink1Description: http://www.ftdichip.com/Support/Documents/DataSheets/ICs/DS_FT230X.pdf; Component Kind: Standard; Category: Integrated Circuits (ICs)
U4-U6 = DRV8876QPWPRQ1: No Description Available; Vendor: Texas Instruments; SourceLibrary: TI_2020-07-01; Built_By: EMA_Matt; Type: DEV; RefDes: RefDes; Manufacturer_Part_Number: DRV8876QPWPRQ1; Library Name: DRV8876.SchLib; Datasheet: https://www.ti.com/lit/ds/symlink/drv8876-q1.pdf?ts=1593552747152&ref_url=https%253A%252F%252Fwww.ti.com%252Fproduct%252FDRV8876-Q1; Component Kind: Standard; Copyright: Copyright (C) 2018 Accelerated Designs. All rights reserved
USB1 = MOLEX 0670688010: Supplier 1: Digi-Key; Library Name: Connectors.SchLib; Description: USB type-B jack; Component Kind: Standard
X1 = 403C35E16M00000: CRYSTAL 16MHZ 20PF SMD; Manufacturer: CTS-Frequency Controls; Operating Mode: Fundamental; Frequency Tolerance: ±30ppm; Frequency Stability: ±50ppm; ESR (Equivalent Series Resistance): 80 Ohm; Type: MHz Crystal; Supplier Part Number 1: CTX1293CT-ND; Supplier 1: Digi-Key; Stock 1: 7029; Size / Dimension: 0.126" L x 0.098" W (3.20mm x 2.50mm); RoHS: RoHS Compliant; Ratings: -; Pricing 1: 1=1.07, 10=0.95, 50=0.8866, 100=0.7847, 500=0.7415, 750=0.70228, 1000=0.61988 (CAD); Packaging: Cut Tape (CT); Package / Case: 4-SMD, No Lead (DFN, LCC); Operating Temperature: -20°C ~ 70°C; Mounting Type: Surface Mount; Manufacturer Part Number: 403C35E16M00000; Load Capacitance: 20pF; Library Name: ICs.SchLib; Height: 0.031" (0.80mm); Frequency: 16MHz; ComponentLink1URL: http://www.ctscorp.com/components/Datasheets/008-0288-0_F.pdf; ComponentLink1Description: http://www.ctscorp.com/components/Datasheets/008-0288-0_F.pdf; Component Kind: Standard; Category: Crystals and Oscillators
P8 = 76825-0006: Library Name: Molex 76825-0006.SchLib; Component Kind: Standard
